# Altium schematic sheet 拉伸机原理图.SchDoc
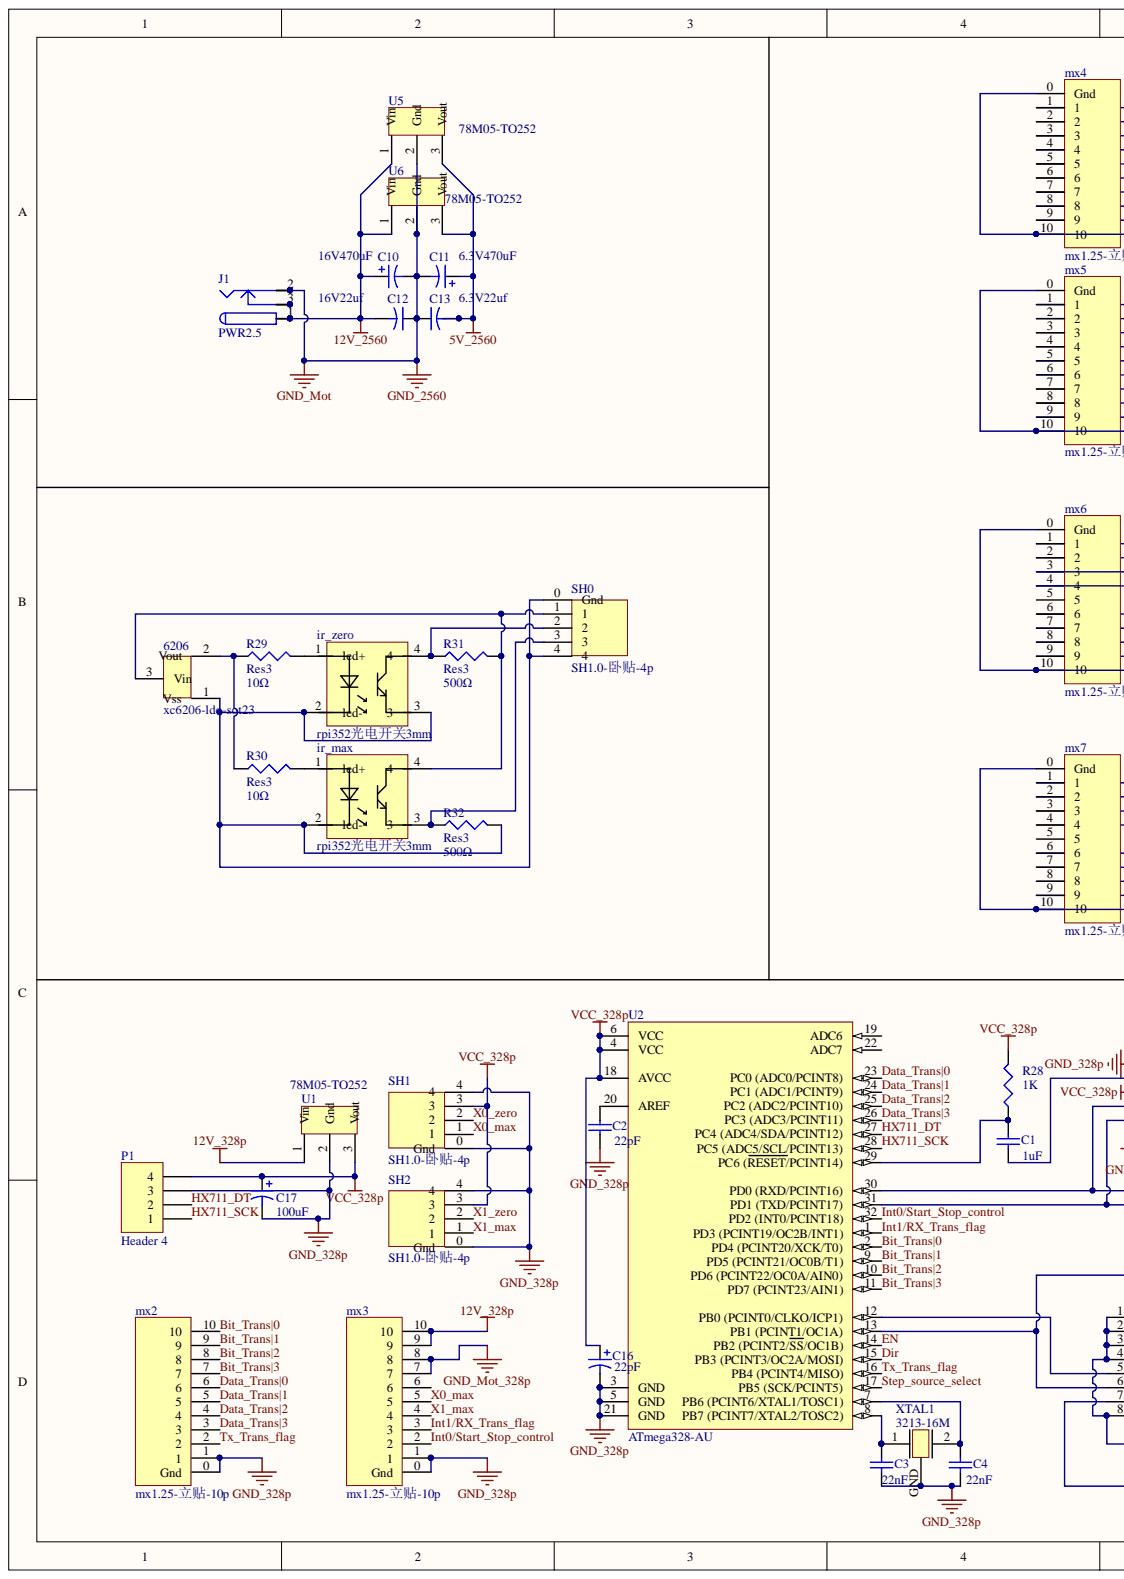
[diagram p0: 拉伸机原理图.SchDoc, left]
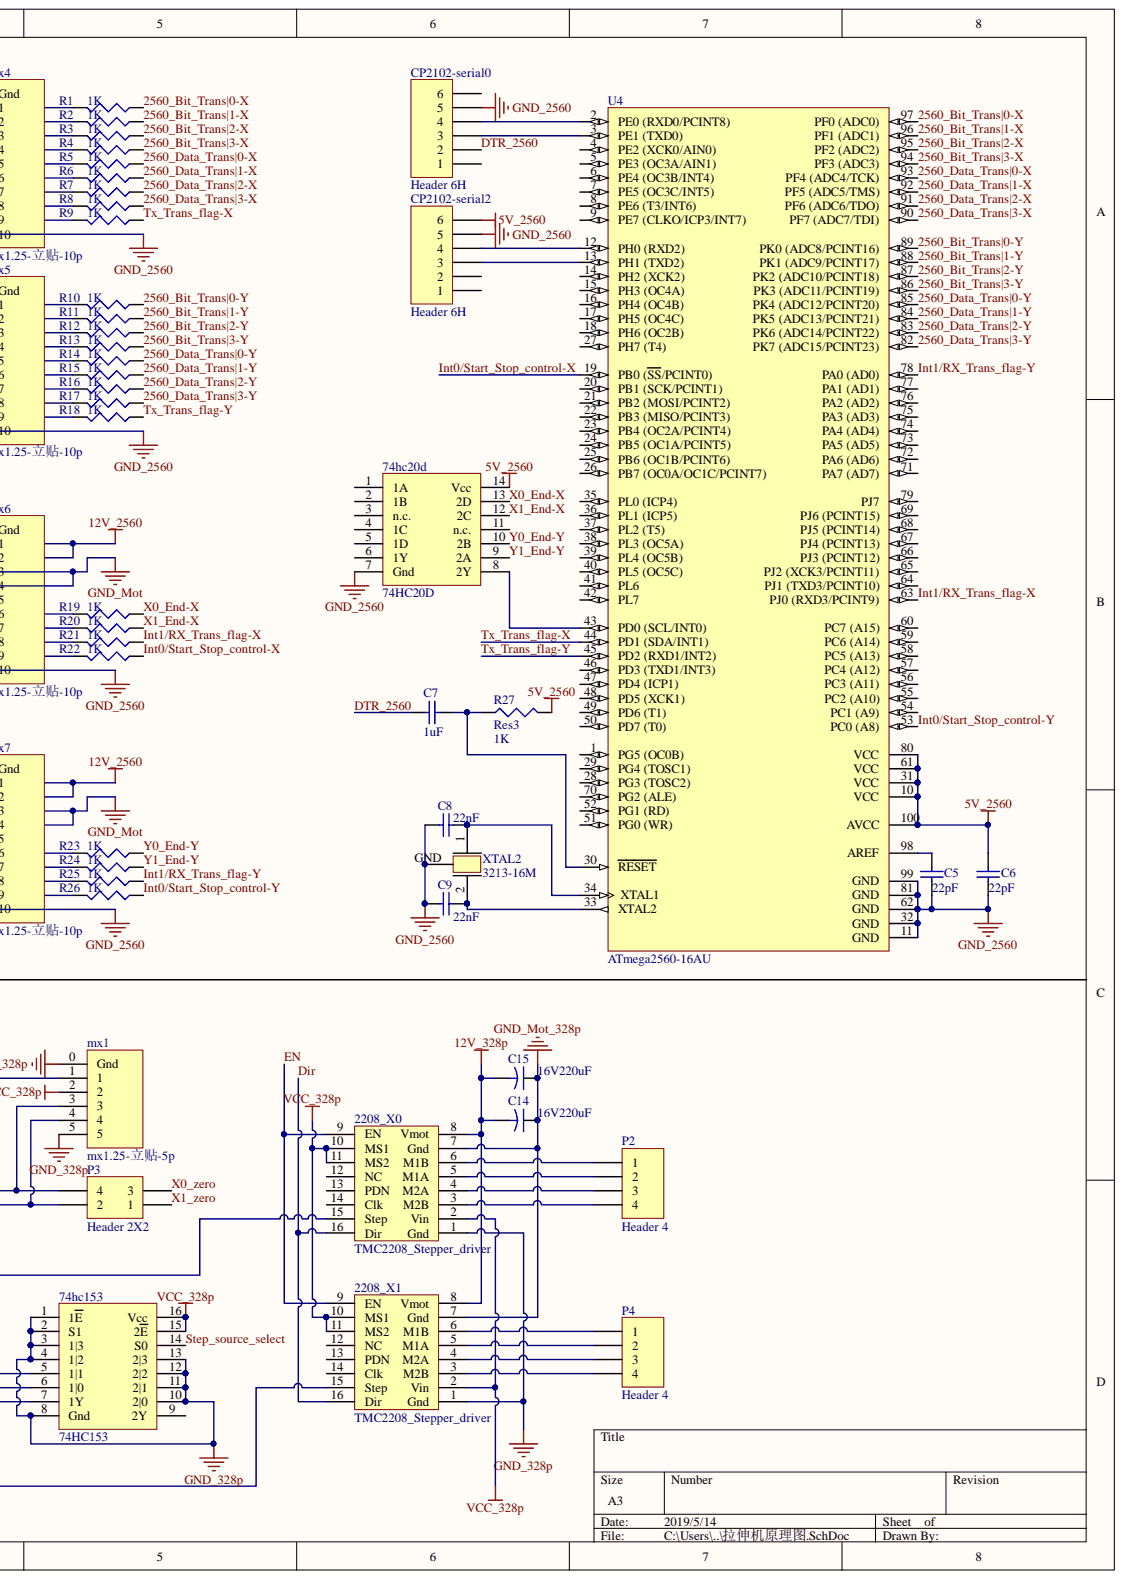
[diagram p1: 拉伸机原理图.SchDoc, right]

## Parts
74hc20d  74HC20D  [SOP14]
74hc153  74HC153  [SO-16_L]
2208_X0  TMC2208_Stepper_driver  [TMC2208/A4988]
2208_X1  TMC2208_Stepper_driver  [TMC2208/A4988]
6206  xc6206-ldo-sot23  [SOT-23_N]
C1  value 1uF  [1608[0603]]  (hidden comment: Cap Semi)
C2  value 22pF  [1608[0603]]  (hidden comment: Cap Semi)
C3  value 22nF  [1608[0603]]  (hidden comment: Cap Semi)
C4  value 22nF  [1608[0603]]  (hidden comment: Cap Semi)
C5  value 22pF  [1608[0603]]  (hidden comment: Cap Semi)
C6  value 22pF  [1608[0603]]  (hidden comment: Cap Semi)
C7  value 1uF  [1608[0603]]  (hidden comment: Cap Semi)
C8  value 22nF  [1608[0603]]  (hidden comment: Cap Semi)
C9  value 22nF  [1608[0603]]  (hidden comment: Cap Semi)
C10  value 16V470uF  [1812]  (hidden comment: Cap Pol3)
C11  value 6.3V470uF  [1812]  (hidden comment: Cap Pol3)
C12  value 16V22uf  [CAPR5-4X5]  (hidden comment: Cap2)
C13  value 6.3V22uf  [CAPR5-4X5]  (hidden comment: Cap2)
C14  value 16V220uF  [CAPR5-4X5]  (hidden comment: Cap2)
C15  value 16V220uF  [CAPR5-4X5]  (hidden comment: Cap2)
C16  value 22pF  [1812]  (hidden comment: Cap Pol3)
C17  value 100uF  [1812]  (hidden comment: Cap Pol3)
CP2102-serial0  Header 6H  [HDR1X6H]
CP2102-serial2  Header 6H  [HDR1X6H]
ir_max  rpi352光电开关3mm  [rpi-352-j 光电开关]
ir_zero  rpi352光电开关3mm  [rpi-352-j 光电开关]
J1  PWR2.5  [KLD-0202]
mx1  mx1.25-立贴-5p  [mx1.25-立贴-5p]
mx2  mx1.25-立贴-10p  [mx1.25-立贴-10p]
mx3  mx1.25-立贴-10p  [mx1.25-立贴-10p]
mx4  mx1.25-立贴-10p  [mx1.25-立贴-10p]
mx5  mx1.25-立贴-10p  [mx1.25-立贴-10p]
mx6  mx1.25-立贴-10p  [mx1.25-立贴-10p]
mx7  mx1.25-立贴-10p  [mx1.25-立贴-10p]
P1  Header 4  [HDR1X4]
P2  Header 4  [HDR1X4]
P3  Header 2X2  [HDR2X2]
P4  Header 4  [HDR1X4]
R1  value 1K  [J1-0603]  (hidden comment: Res3)
R2  value 1K  [J1-0603]  (hidden comment: Res3)
R3  value 1K  [J1-0603]  (hidden comment: Res3)
R4  value 1K  [J1-0603]  (hidden comment: Res3)
R5  value 1K  [J1-0603]  (hidden comment: Res3)
R6  value 1K  [J1-0603]  (hidden comment: Res3)
R7  value 1K  [J1-0603]  (hidden comment: Res3)
R8  value 1K  [J1-0603]  (hidden comment: Res3)
R9  value 1K  [J1-0603]  (hidden comment: Res3)
R10  value 1K  [J1-0603]  (hidden comment: Res3)
R11  value 1K  [J1-0603]  (hidden comment: Res3)
R12  value 1K  [J1-0603]  (hidden comment: Res3)
R13  value 1K  [J1-0603]  (hidden comment: Res3)
R14  value 1K  [J1-0603]  (hidden comment: Res3)
R15  value 1K  [J1-0603]  (hidden comment: Res3)
R16  value 1K  [J1-0603]  (hidden comment: Res3)
R17  value 1K  [J1-0603]  (hidden comment: Res3)
R18  value 1K  [J1-0603]  (hidden comment: Res3)
R19  value 1K  [J1-0603]  (hidden comment: Res3)
R20  value 1K  [J1-0603]  (hidden comment: Res3)
R21  value 1K  [J1-0603]  (hidden comment: Res3)
R22  value 1K  [J1-0603]  (hidden comment: Res3)
R23  value 1K  [J1-0603]  (hidden comment: Res3)
R24  value 1K  [J1-0603]  (hidden comment: Res3)
R25  value 1K  [J1-0603]  (hidden comment: Res3)
R26  value 1K  [J1-0603]  (hidden comment: Res3)
R27  Res3  value 1K  [J1-0603]
R28  value 1K  [J1-0603]  (hidden comment: Res3)
R29  Res3  value 10Ω  [J1-0603]
R30  Res3  value 10Ω  [J1-0603]
R31  Res3  value 500Ω  [J1-0603]
R32  Res3  value 500Ω  [J1-0603]
SH0  SH1.0-卧贴-4p  [SH1.0-卧贴-4p]
SH1  SH1.0-卧贴-4p  [SH1.0-卧贴-4p]
SH2  SH1.0-卧贴-4p  [SH1.0-卧贴-4p]
U1  78M05-TO252  [78M05-TO252]
U2  ATmega328-AU  [32A_N]
U4  ATmega2560-16AU  [100A_N]
U5  78M05-TO252  [78M05-TO252]
U6  78M05-TO252  [78M05-TO252]
XTAL1  3213-16M  [3213-xtal]
XTAL2  3213-16M  [3213-xtal]

## Nets
5V_2560: 74hc20d.14(Vcc) C6.2 C11.1 C13.2 CP2102-serial2.6 R27.2 U4.10(VCC) U4.31(VCC) U4.61(VCC) U4.80(VCC) U4.100(AVCC) U5.3 U6.3
12V_328P: 2208_X0.8(Vmot) 2208_X1.8(Vmot) C14.2 C15.2 mx3.9 mx3.10 U1.1
12V_2560: C10.1 C12.2 J1.1 J1.1A J1.1B mx6.1 mx6.2 mx7.1 mx7.2 U5.1 U6.1
GND_328P: 74hc153.1(1E) 74hc153.2(S1) 74hc153.3(1|3) 74hc153.4(1|2) 74hc153.8(Gnd) 74hc153.10(2|0) 74hc153.11(2|1) 74hc153.12(2|2) 74hc153.13(2|3) 2208_X0.1(Gnd) 2208_X1.1(Gnd) C2.1 C3.1 C4.1 C16.2 C17.2 mx1.0(Gnd) mx1.5 mx2.0(Gnd) mx2.1 mx3.0(Gnd) mx3.1 P1.3 SH1.0(Gnd) SH1.4 SH2.0(Gnd) SH2.4 U1.2 U2.3(GND) U2.5(GND) U2.21(GND) XTAL1.GND
GND_2560: 74hc20d.7(Gnd) C5.1 C6.1 C8.1 C9.1 C10.2 C11.2 C12.1 C13.1 CP2102-serial0.5 CP2102-serial2.5 J1.2 J1.2A J1.2B mx4.0(Gnd) mx4.10 mx5.0(Gnd) mx5.10 mx6.0(Gnd) mx6.3 mx6.4 mx6.10 mx7.0(Gnd) mx7.3 mx7.4 mx7.10 U4.11(GND) U4.32(GND) U4.62(GND) U4.81(GND) U4.99(GND) U5.2 U6.2 XTAL2.GND
GND_MOT_328P: 2208_X0.7(Gnd) 2208_X1.7(Gnd) C14.1 C15.1 mx3.7 mx3.8
VCC_328P: 74hc153.15(2E) 74hc153.16(Vcc) 2208_X0.2(Vin) 2208_X0.10(MS1) 2208_X0.11(MS2) 2208_X1.2(Vin) 2208_X1.10(MS1) 2208_X1.11(MS2) C16.1 C17.1 mx1.2 P1.4 R28.2 SH1.3 SH2.3 U1.3 U2.4(VCC) U2.6(VCC) U2.18(AVCC)
2560_BIT_TRANS|0-X: R1.1 U4.97(PF0_(ADC0))
2560_BIT_TRANS|0-Y: R10.1 U4.89(PK0_(ADC8/PCINT16))
2560_BIT_TRANS|1-X: R2.1 U4.96(PF1_(ADC1))
2560_BIT_TRANS|1-Y: R11.1 U4.88(PK1_(ADC9/PCINT17))
2560_BIT_TRANS|2-X: R3.1 U4.95(PF2_(ADC2))
2560_BIT_TRANS|2-Y: R12.1 U4.87(PK2_(ADC10/PCINT18))
2560_BIT_TRANS|3-X: R4.1 U4.94(PF3_(ADC3))
2560_BIT_TRANS|3-Y: R13.1 U4.86(PK3_(ADC11/PCINT19))
2560_DATA_TRANS|0-X: R5.1 U4.93(PF4_(ADC4/TCK))
2560_DATA_TRANS|0-Y: R14.1 U4.85(PK4_(ADC12/PCINT20))
2560_DATA_TRANS|1-X: R6.1 U4.92(PF5_(ADC5/TMS))
2560_DATA_TRANS|1-Y: R15.1 U4.84(PK5_(ADC13/PCINT21))
2560_DATA_TRANS|2-X: R7.1 U4.91(PF6_(ADC6/TDO))
2560_DATA_TRANS|2-Y: R16.1 U4.83(PK6_(ADC14/PCINT22))
2560_DATA_TRANS|3-X: R8.1 U4.90(PF7_(ADC7/TDI))
2560_DATA_TRANS|3-Y: R17.1 U4.82(PK7_(ADC15/PCINT23))
BIT_TRANS|0: mx2.10 U2.2(PD4_(PCINT20/XCK/T0))
BIT_TRANS|1: mx2.9 U2.9(PD5_(PCINT21/OC0B/T1))
BIT_TRANS|2: mx2.8 U2.10(PD6_(PCINT22/OC0A/AIN0))
BIT_TRANS|3: mx2.7 U2.11(PD7_(PCINT23/AIN1))
DATA_TRANS|0: mx2.6 U2.23(PC0_(ADC0/PCINT8))
DATA_TRANS|1: mx2.5 U2.24(PC1_(ADC1/PCINT9))
DATA_TRANS|2: mx2.4 U2.25
DATA_TRANS|3: mx2.3 U2.26(PC3_(ADC3/PCINT11))
DIR: 2208_X0.16(Dir) 2208_X1.16(Dir) U2.15(PB3_(PCINT3/OC2A/MOSI))
DTR_2560: C7.2 CP2102-serial0.2
EN: 2208_X0.9(EN) 2208_X1.9(EN) U2.14(PB2_(PCINT2/SS/OC1B))
HX711_DT: P1.2 U2.27(PC4_(ADC4/SDA/PCINT12))
HX711_SCK: P1.1 U2.28(PC5_(ADC5/SCL/PCINT13))
INT0/START_STOP_CONTROL: mx3.2 U2.32(PD2_(INT0/PCINT18))
INT0/START_STOP_CONTROL-X: R22.1 U4.19(PB0_(SS/PCINT0))
INT0/START_STOP_CONTROL-Y: R26.1 U4.53(PC0_(A8))
INT1/RX_TRANS_FLAG: mx3.3 U2.1(PD3_(PCINT19/OC2B/INT1))
INT1/RX_TRANS_FLAG-X: R21.1 U4.63(PJ0_(RXD3/PCINT9))
INT1/RX_TRANS_FLAG-Y: R25.1 U4.78(PA0_(AD0))
STEP_SOURCE_SELECT: 74hc153.14(S0) U2.17(PB5_(SCK/PCINT5))
TX_TRANS_FLAG: mx2.2 U2.16(PB4_(PCINT4/MISO))
TX_TRANS_FLAG-X: R9.1 U4.44(PD1_(SDA/INT1))
TX_TRANS_FLAG-Y: R18.1 U4.45(PD2_(RXD1/INT2))
X0_END-X: 74hc20d.13(2D) R19.1
X0_MAX: mx3.5 SH1.1
X0_ZERO: P3.3 SH1.2
X1_END-X: 74hc20d.12(2C) R20.1
X1_MAX: mx3.4 SH2.1
X1_ZERO: P3.1 SH2.2
Y0_END-Y: 74hc20d.10(2B) R23.1
Y1_END-Y: 74hc20d.9(2A) R24.1
Net74hc20d_8: 74hc20d.8(2Y) U4.43(PD0_(SCL/INT0))
Net74hc153_5: 74hc153.5(1|1) U2.12(PB0_(PCINT0/CLKO/ICP1))
Net74hc153_6: 74hc153.6(1|0) 2208_X0.15(Step) U2.13(PB1_(PCINT1/OC1A))
Net74hc153_7: 74hc153.7(1Y) 2208_X1.15(Step)
Net2208_X0_3: 2208_X0.3(M2B) P2.4
Net2208_X0_4: 2208_X0.4(M2A) P2.3
Net2208_X0_5: 2208_X0.5(M1A) P2.2
Net2208_X0_6: 2208_X0.6(M1B) P2.1
Net2208_X1_3: 2208_X1.3(M2B) P4.4
Net2208_X1_4: 2208_X1.4(M2A) P4.3
Net2208_X1_5: 2208_X1.5(M1A) P4.2
Net2208_X1_6: 2208_X1.6(M1B) P4.1
Net6206_1: 6206.1 ir_max.2(led-) ir_zero.2(led-) ir_zero.3 R32.2 SH0.0(Gnd) SH0.4
Net6206_2: 6206.2 R29.1 R30.1
Net6206_3: 6206.3 ir_max.4 R31.2 SH0.1
NetC1_1: C1.1 mx1.1
NetC1_2: C1.2 R28.1 U2.29(PC6_(RESET/PCINT14))
NetC2_2: C2.2 U2.20(AREF)
NetC3_2: C3.2 U2.8 XTAL1.1
NetC4_2: C4.2 U2.7 XTAL1.2
NetC5_2: C5.2 U4.98(AREF)
NetC7_1: C7.1 R27.1 U4.30(RESET)
NetC8_2: C8.2 U4.34(XTAL1) XTAL2.1
NetC9_2: C9.2 U4.33(XTAL2) XTAL2.2
NetCP2102-serial0_3: CP2102-serial0.3 U4.3(PE1_(TXD0))
NetCP2102-serial0_4: CP2102-serial0.4 U4.2(PE0_(RXD0/PCINT8))
NetCP2102-serial2_3: CP2102-serial2.3 U4.13(PH1_(TXD2))
NetCP2102-serial2_4: CP2102-serial2.4 U4.12(PH0_(RXD2))
Netir_max_1: ir_max.1(led+) R30.2
Netir_max_3: ir_max.3 R32.1 SH0.3
Netir_zero_1: ir_zero.1(led+) R29.2
Netir_zero_4: ir_zero.4 R31.1 SH0.2
NetJ1_3: J1.3 J1.3A J1.3B
Netmx1_3: mx1.3 P3.4 U2.30(PD0_(RXD/PCINT16))
Netmx1_4: mx1.4 P3.2 U2.31(PD1_(TXD/PCINT17))
Netmx4_1: mx4.1 R1.2
Netmx4_2: mx4.2 R2.2
Netmx4_3: mx4.3 R3.2
Netmx4_4: mx4.4 R4.2
Netmx4_5: mx4.5 R5.2
Netmx4_6: mx4.6 R6.2
Netmx4_7: mx4.7 R7.2
Netmx4_8: mx4.8 R8.2
Netmx4_9: mx4.9 R9.2
Netmx5_1: mx5.1 R10.2
Netmx5_2: mx5.2 R11.2
Netmx5_3: mx5.3 R12.2
Netmx5_4: mx5.4 R13.2
Netmx5_5: mx5.5 R14.2
Netmx5_6: mx5.6 R15.2
Netmx5_7: mx5.7 R16.2
Netmx5_8: mx5.8 R17.2
Netmx5_9: mx5.9 R18.2
Netmx6_6: mx6.6 R19.2
Netmx6_7: mx6.7 R20.2
Netmx6_8: mx6.8 R21.2
Netmx6_9: mx6.9 R22.2
Netmx7_6: mx7.6 R23.2
Netmx7_7: mx7.7 R24.2
Netmx7_8: mx7.8 R25.2
Netmx7_9: mx7.9 R26.2

Not connected: 74hc20d 1(1A) 2(1B) 3(n.c.) 4(1C) 5(1D) 6(1Y) 11(n.c.); 74hc153 9(2Y); 2208_X0 12(NC) 13(PDN) 14(Clk); 2208_X1 12(NC) 13(PDN) 14(Clk); CP2102-serial0 1 6; CP2102-serial2 1 2; mx3 6; mx6 5; mx7 5; U2 19(ADC6) 22(ADC7); U4 1(PG5_(OC0B)) 4(PE2_(XCK0/AIN0)) 5(PE3_(OC3A/AIN1)) 6(PE4_(OC3B/INT4)) 7(PE5_(OC3C/INT5)) 8(PE6_(T3/INT6)) 9(PE7_(CLKO/ICP3/INT7)) 14(PH2_(XCK2)) 15(PH3_(OC4A)) 16(PH4_(OC4B)) 17(PH5_(OC4C)) 18(PH6_(OC2B)) 20(PB1_(SCK/PCINT1)) 21(PB2_(MOSI/PCINT2)) 22(PB3_(MISO/PCINT3)) 23(PB4_(OC2A/PCINT4)) 24(PB5_(OC1A/PCINT5)) 25(PB6_(OC1B/PCINT6)) 26(PB7_(OC0A/OC1C/PCINT7)) 27(PH7_(T4)) 28(PG3_(TOSC2)) 29(PG4_(TOSC1)) 35(PL0_(ICP4)) 36(PL1_(ICP5)) 37(PL2_(T5)) 38(PL3_(OC5A)) 39(PL4_(OC5B)) 40(PL5_(OC5C)) 41(PL6) 42(PL7) 46(PD3_(TXD1/INT3)) 47(PD4_(ICP1)) 48(PD5_(XCK1)) 49(PD6_(T1)) 50(PD7_(T0)) 51(PG0_(WR)) 52(PG1_(RD)) 54(PC1_(A9)) 55(PC2_(A10)) 56(PC3_(A11)) 57(PC4_(A12)) 58(PC5_(A13)) 59(PC6_(A14)) 60(PC7_(A15)) 64(PJ1_(TXD3/PCINT10)) 65(PJ2_(XCK3/PCINT11)) 66(PJ3_(PCINT12)) 67(PJ4_(PCINT13)) 68(PJ5_(PCINT14)) 69(PJ6_(PCINT15)) 70(PG2_(ALE)) 71(PA7_(AD7)) 72(PA6_(AD6)) 73(PA5_(AD5)) 74(PA4_(AD4)) 75(PA3_(AD3)) 76(PA2_(AD2)) 77(PA1_(AD1)) 79(PJ7)

## Library data of the parts
C1-C9 = Cap Semi: Capacitor (Semiconductor SIM Model); Component Kind: Standard; LatestRevisionDate: 29-May-2009; LatestRevisionNote: IPC-7351 Footprint Added.; Library Name: Miscellaneous Devices.IntLib; PackageDescription: Chip Capacitor; PackageReference: C1206; Published: 8-Jun-2000; Signal Integrity: Cap Semi; Simulation: CAP; Publisher: Altium Limited
C10 C11 C16 C17 = Cap Pol3: Polarized Capacitor (Surface Mount); Component Kind: Standard; LatestRevisionDate: 29-May-2009; LatestRevisionNote: IPC-7351 Footprint Added.; Library Name: Miscellaneous Devices.IntLib; PackageDescription: Chip Capacitor; PackageReference: C0805; Published: 8-Jun-2000; Signal Integrity: Cap Pol; Simulation: CAP; Publisher: Altium Limited
C12-C15 = Cap2: Capacitor; Component Kind: Standard; Library Name: Miscellaneous Devices.IntLib; PackageDescription: Capacitor; 2 Leads; PackageReference: CAPR5-4X5; Published: 23-Sep-2002; Signal Integrity: Cap; Simulation: CAP; Publisher: Altium Limited
CP2102-serial0 CP2102-serial2 = Header 6H: Header, 6-Pin, Right Angle; Component Kind: Standard; LatestRevisionDate: 17-Jul-2002; LatestRevisionNote: Re-released for DXP Platform.; Library Name: Miscellaneous Connectors.IntLib; Signal Integrity: Connector; Publisher: Altium Limited
J1 = PWR2.5: Low Voltage Power Supply Connector; Component Kind: Standard; ComponentLink1Description: Manufacturer Link; ComponentLink1URL: http://domino.kycon.com/internet/Products.html; DatasheetDocument: 09-May-2002; Library Name: Miscellaneous Connectors.IntLib; Published: 17-Dec-2003; Signal Integrity: Connector; Publisher: Altium Limited
P1 P2 P4 = Header 4: Header, 4-Pin; Component Kind: Standard; LatestRevisionDate: 17-Jul-2002; LatestRevisionNote: Re-released for DXP Platform.; Library Name: Miscellaneous Connectors.IntLib; Signal Integrity: Connector; Publisher: Altium Limited
P3 = Header 2X2: Header, 2-Pin, Dual row; Component Kind: Standard; LatestRevisionDate: 17-Jul-2002; LatestRevisionNote: Re-released for DXP Platform.; Library Name: Miscellaneous Connectors.IntLib; Signal Integrity: Connector; Publisher: Altium Limited
R1-R32 = Res3: Resistor; Component Kind: Standard; LatestRevisionDate: 29-May-2009; LatestRevisionNote: IPC-7351 Footprint Added.; Library Name: Miscellaneous Devices.IntLib; PackageDescription: Chip Resistor; PackageReference: J1-0603; Published: 8-Jun-2000; Signal Integrity: Res; Simulation: RESISTOR; Publisher: Altium Limited
U2 = ATmega328-AU: 8-bit AVR Microcontroller, 32KB Flash, 1KB EEPROM, 2KB SRAM, 32-pin TQFP, Industrial Grade (-40癈 to 85癈); Code_JEDEC: MS-026-ABA; Component Kind: Standard; ComponentLink1Description: Manufacturer Link; ComponentLink1URL: http://www.atmel.com/products/avr/default.asp?; ComponentLink2Description: ATmega48A/48PA/88A/88PA/168A/168PA/328/328P Datasheet; ComponentLink2URL: http://www.atmel.com/dyn/resources/prod_documents/8271.pdf; ComponentLink3Description: ATmega48A/48PA/88A/88PA/168A/168PA/328/328P Summary; ComponentLink3URL: http://www.atmel.com/dyn/resources/prod_documents/8271S.pdf; ComponentLink4Description: Atmel.com Home; ComponentLink4URL: http://www.atmel.com; DatasheetVersion: revA, Jan-2010; Library Name: Atmel Microcontroller 8-Bit megaAVR.IntLib; PackageDescription: 32-Lead, 7x7x1.0 mm Body, 0.8mm Lead Pitch, Thin Profile Plastic Quad Flat Package (TQFP); PackageReference: 32A; PackageVersion: revB, Oct-2001; Published: 1-Apr-2010; Supplier 1: Digi-Key; Supplier 2: Mouser; Supplier Part Number 1: ATMEGA328-AU-ND; Supplier Part Number 2: 556-ATMEGA328-AU; Publisher: Altium Limited
U4 = ATmega2560-16AU: 8-bit AVR Microcontroller, 4.5-5.5V, 256KB Flash, 4KB EEPROM, 8KB SRAM, 86 GPIO pins, 100-pin TQFP, Industrial Grade (-40癈 to 85癈), Pb-Free; Code_JEDEC: MS-026-AED; Component Kind: Standard; ComponentLink1Description: Manufacturer Link; ComponentLink1URL: http://www.atmel.com/products/avr/default.asp?; ComponentLink2Description: ATmega640/1280/1281/2560/2561 Datasheet; ComponentLink2URL: http://www.atmel.com/dyn/resources/prod_documents/doc2549.pdf; ComponentLink3Description: ATmega640/1280/1281/2560/2561 Summary; ComponentLink3URL: http://www.atmel.com/dyn/resources/prod_documents/2549S.pdf; ComponentLink4Description: Atmel.com Home; ComponentLink4URL: http://www.atmel.com; DatasheetVersion: revL, Aug-2007; Library Name: Atmel Microcontroller 8-Bit megaAVR.IntLib; PackageDescription: 100-Pin Thin Profile Plastic Quad Flat Pack (TQFP), 14 x 14 x 1.0 mm Body, 0.5 mm Pitch; PackageReference: 100A; PackageVersion: revC, Oct-2001; Published: 1-Apr-2010; Supplier 1: Digi-Key; Supplier 2: Farnell; Supplier 3: Farnell; Supplier 4: Mouser; Supplier Part Number 1: ATMEGA2560-16AU-ND; Supplier Part Number 2: 1288330; Supplier Part Number 3: 1661740; Supplier Part Number 4: 556-ATMEGA2560-16AU; Publisher: Altium Limited
XTAL1 XTAL2 = 3213-xtal: Component Kind: Standard; Library Name: Schlib1.SchLib
74hc20d = 74HC20D: Component Kind: Standard; Library Name: Schlib1.SchLib
74hc153 = 74HC153: Component Kind: Standard; Library Name: Schlib1.SchLib
2208_X0 2208_X1 = TMC2208_Stepper_driver: Component Kind: Standard; Library Name: Schlib1.SchLib
6206 = xc6206-ldo-sot23: Component Kind: Standard; Library Name: Schlib1.SchLib
ir_max ir_zero = rpi352光电开关3mm: Component Kind: Standard; Library Name: Schlib1.SchLib
mx1 = mx1.25-立贴-5p: Component Kind: Standard; Library Name: Connectors.SchLib
mx2-mx7 = mx1.25-立贴-10p: Component Kind: Standard; Library Name: Connectors.SchLib
SH0-SH2 = SH1.0-卧贴-4p: Component Kind: Standard; Library Name: Connectors.SchLib
U1 U5 U6 = 78M05-TO252: Component Kind: Standard; Library Name: Schlib1.SchLib
